# Altium schematic sheet LiDo_uC_Board_Power.SchDoc
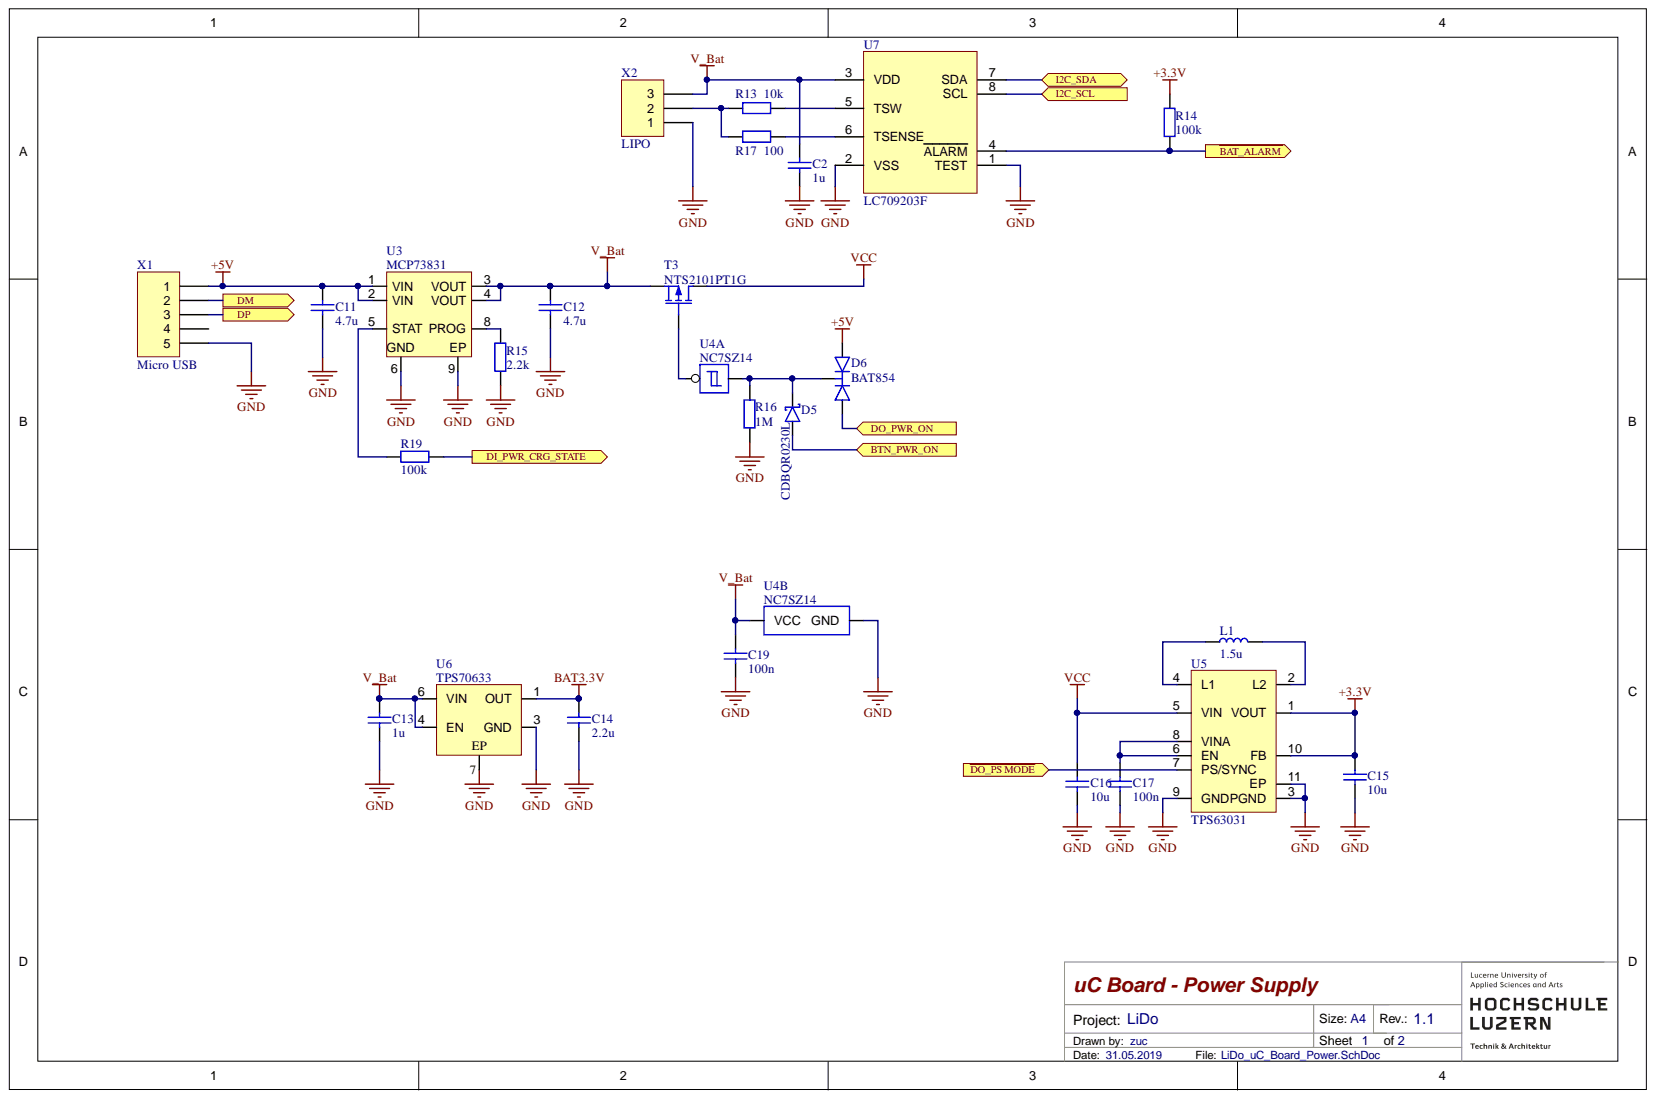
[diagram p0: LiDo_uC_Board_Power.SchDoc]

## Parts
C2  1u  [0402s]
C11  4.7u  [0402S]
C12  4.7u  [0402S]
C13  1u  [0402S]
C14  2.2u  [0402S]
C15  10u  [0402S]
C16  10u  [0402S]
C17  100n  [0402S]
C19  100n  [0402S]
D5  CDBQR0230L  [0402d]
D6  BAT854  [SOT-323]
L1  1.5u  [0805s]
R13  10k  [0402s]
R14  100k  [0402S]
R15  2.2k  [0402S]
R16  1M  [0402S]
R17  100  [0402s]
R19  100k  [0402S]
T3  NTS2101PT1G  [SOT-323]
U3  MCP73831  [DFN50P200X300X90-8N]
U4  NC7SZ14  [SOT-323-5]  (parts A,B)
U5  TPS63031  [DFN50P250X250X80-10N]
U6  TPS70633  [WSON65P210X210X80-6N]
U7  LC709203F  [DFN65P300X400X80-8N - duplicate]
X1  Micro USB  [MICRO_USB_105017-001]
X2  LIPO  [CON_53261-0371]

## Ports
BTN_PWR_ON, DI_PWR_CRG_STATE, DM, DO_PWR_ON, DP, I2C_SCL, I2C_SDA, ~{BAT_ALARM}, ~{DO_PS MODE}

## Nets
+3.3V: C15.2 R14.2 U5.1(VOUT) U5.10(FB)
+5V: C11.2 D6.2 U3.1(VIN) U3.2(VIN) X1.1
BAT3.3V: C14.2 U6.1(OUT)
GND: C2.1 C11.1 C12.1 C13.1 C14.1 C15.1 C16.1 C17.1 C19.1 R15.1 R16.1 U3.6 U3.9(EP) U4.3(GND) U5.3(PGND) U5.9(GND) U5.11(EP) U6.3(GND) U6.7(EP) U7.1(TEST) U7.2(VSS) X1.5 X2.1
V_Bat: C2.2 C12.2 C13.2 C19.2 T3.3 U3.3(VOUT) U3.4(VOUT) U4.5(VCC) U6.4(EN) U6.6(VIN) U7.3(VDD) X2.3
VCC: C16.2 T3.1 U5.5(VIN)
~{BAT_ALARM}: R14.1 U7.4(ALARM) ; port ~{BAT_ALARM}
BTN_PWR_ON: D5.1 ; port BTN_PWR_ON
~{DO_PS MODE}: U5.7(PS/SYNC) ; port ~{DO_PS MODE}
DI_PWR_CRG_STATE: R19.1 ; port DI_PWR_CRG_STATE
DM: X1.2 ; port DM
DO_PWR_ON: D6.1 ; port DO_PWR_ON
DP: X1.3 ; port DP
I2C_SCL: U7.8(SCL) ; port I2C_SCL
I2C_SDA: U7.7(SDA) ; port I2C_SDA
NetC17_2: C17.2 U5.6(EN) U5.8(VINA)
NetD5_2: D5.2 D6.3 R16.2 U4.2
NetL1_1: L1.1 U5.4(L1)
NetL1_2: L1.2 U5.2(L2)
NetR13_1: R13.1 U7.5(TSW)
NetR13_2: R13.2 R17.2 X2.2
NetR15_2: R15.2 U3.8(PROG)
NetR17_1: R17.1 U7.6(TSENSE)
NetR19_2: R19.2 U3.5(STAT)
NetT3_2: T3.2 U4.4

Not connected: X1 4

## Library data of the parts
C2 = CapNP: Chip Capacitor SMD 0603 6.3V X5R 20%; Component Kind: Standard; Distributor: Digikey; Package: 0402; Value: 1u; Library Name: CCE.SCHLIB
C11 C12 C14 = CapNP: Chip Capacitor SMD 0402 6.3V X5R 20%; Component Kind: Standard; Distributor: Digikey; Package: 0402; Library Name: CCE.SCHLIB
  C11 C12: Distributor Nr.: 490-5408-1-ND; Value: 4.7u
  C14: Value: 2.2u
C13 = CapNP: Chip Capacitor SMD 0402 6.3V X5R 10%; Component Kind: Standard; Distributor: Digikey; Distributor Nr.: 311-1438-1-ND; Package: 0402; Value: 1u; Library Name: CCE.SCHLIB
C15 C16 = CapNP: Chip Capacitor SMD 0603 6.3V x5R 20%; Component Kind: Standard; Distributor: Digikey; Distributor Nr.: 311-1729-1-ND; Package: 0402; Value: 10u; Library Name: CCE.SCHLIB
C17 C19 = CapNP: Chip Capacitor SMD 0402 6.3V X6S 20%; Component Kind: Standard; Distributor: Digikey; Distributor Nr.: 490-9998-1-ND; Package: 0402; Value: 100n; Library Name: CCE.SCHLIB
D5 = DiodeSchottky: DIODE SCHOTTKY 30V 200MA 0402; Component Kind: Standard; Distributor: Digikey; Distributor Nr.: 641-1275-1-ND; Package: 0402; Value: CDBQR0230L; Library Name: CCE.SCHLIB
D6 = BAS40-05: VISHAY SEMICONDUCTOR - BAS40-05 - DIODE, SMALL SIGNAL, 40V, SOT; Component Kind: Standard; Distributor: Digikey; Distributor Nr.: 1727-4826-1-ND; Package: SOT-323; Value: BAT854; Library Name: CCE.SCHLIB
L1 = Ind: Inductor SMD 0603 1A 200mOhm; Component Kind: Standard; Distributor: Digikey; Distributor Nr.: 587-4736-1-ND; Package: 0603; Value: 1.5u; Library Name: CCE.SCHLIB
R13-R17 R19 = Res: Chip Resistor SMD 0402 0.1W 1%; Component Kind: Standard; Distributor: Digikey; Package: 0402; Library Name: CCE.SCHLIB
  R13: Value: 10k
  R14 R19: Value: 100k
  R15: Distributor Nr.: P2.20KLCT-ND; Value: 2.2k
  R16: Value: 1M
  R17: Value: 100
T3 = MOSFET-P: P-Kanal MOSFET 30V 4,3 A (Ta) 1,38 W (Ta)SOT-23; Component Kind: Standard; Distributor: Digikey; Distributor Nr.: NTS2101PT1GOSCT-ND; Package: SC-70; Value: NTS2101PT1G; Library Name: CCE.SCHLIB
U3 = MCP73831: Miniature Single-Cell, Fully Integrated Li-Ion, Li-Polymer Charge Management Controllers; Component Kind: Standard; Distributor: Digikey; Distributor Nr.: MCP73831-2ATI/MC-ND; Package: 8-DFN; Value: MCP73831; Library Name: SmartCuffLib.SchLib
U4 = INV_SCHMITT_1x1: Component Kind: Standard; Description: NC7SZ14; Distributor: Mouser; Distributor Nr.: NC7SZ14P5XCT-ND; Package: SC70-5; Value: NC7SZ14; Library Name: CCE.SCHLIB
U5 = TPS63031: High Efficiency Single Inductor 3.3V Buck-Boost Converter With 1-A Switches; Component Kind: Standard; Distributor: Digikey; Distributor Nr.: 296-39461-1-ND; Package: 10SON; Value: TPS63031; Library Name: SmartCuffLib.SchLib
U6 = TPS70633: Linear Voltage Regulator 3.3V Output 150mA 6-WSON (2x2); Component Kind: Standard; Distributor: Digikey; Distributor Nr.: 296-47245-1-ND; Package: WSON6; Value: TPS70633; Library Name: SmartCuffLib.SchLib
U7 = LC709203F_1: Smart LiB Gauge  Battery Fuel Gauge LSI   for 1-Cell Lithium-ion (Li+); Component Kind: Standard; Distributor: Mouser; Distributor Nr.: 863-LC709203FQH01TWG; Value: LC709203F; Library Name: LIDO.SchLib
X1 = Header 5: USB - Mikro-AB, USB 2.0, Buchsenleiste Steckverbinder, 5 Positionen, Oberflächenmontage, rechtwinklig; Component Kind: Standard; Distributor: Digikey; Distributor Nr.: WM1399CT-ND; Library Name: Miscellaneous Connectors.IntLib; Signal Integrity: Connector; Value: Micro USB
X2 = Header 3: Header, 3-Pin; Value: Bat; Component Kind: Standard; Distributor: Digikey; Distributor Nr.: WM7621CT-ND; Library Name: Miscellaneous Connectors.IntLib; Signal Integrity: Connector
